# Altium schematic sheet Vref.SchDoc(Vref)
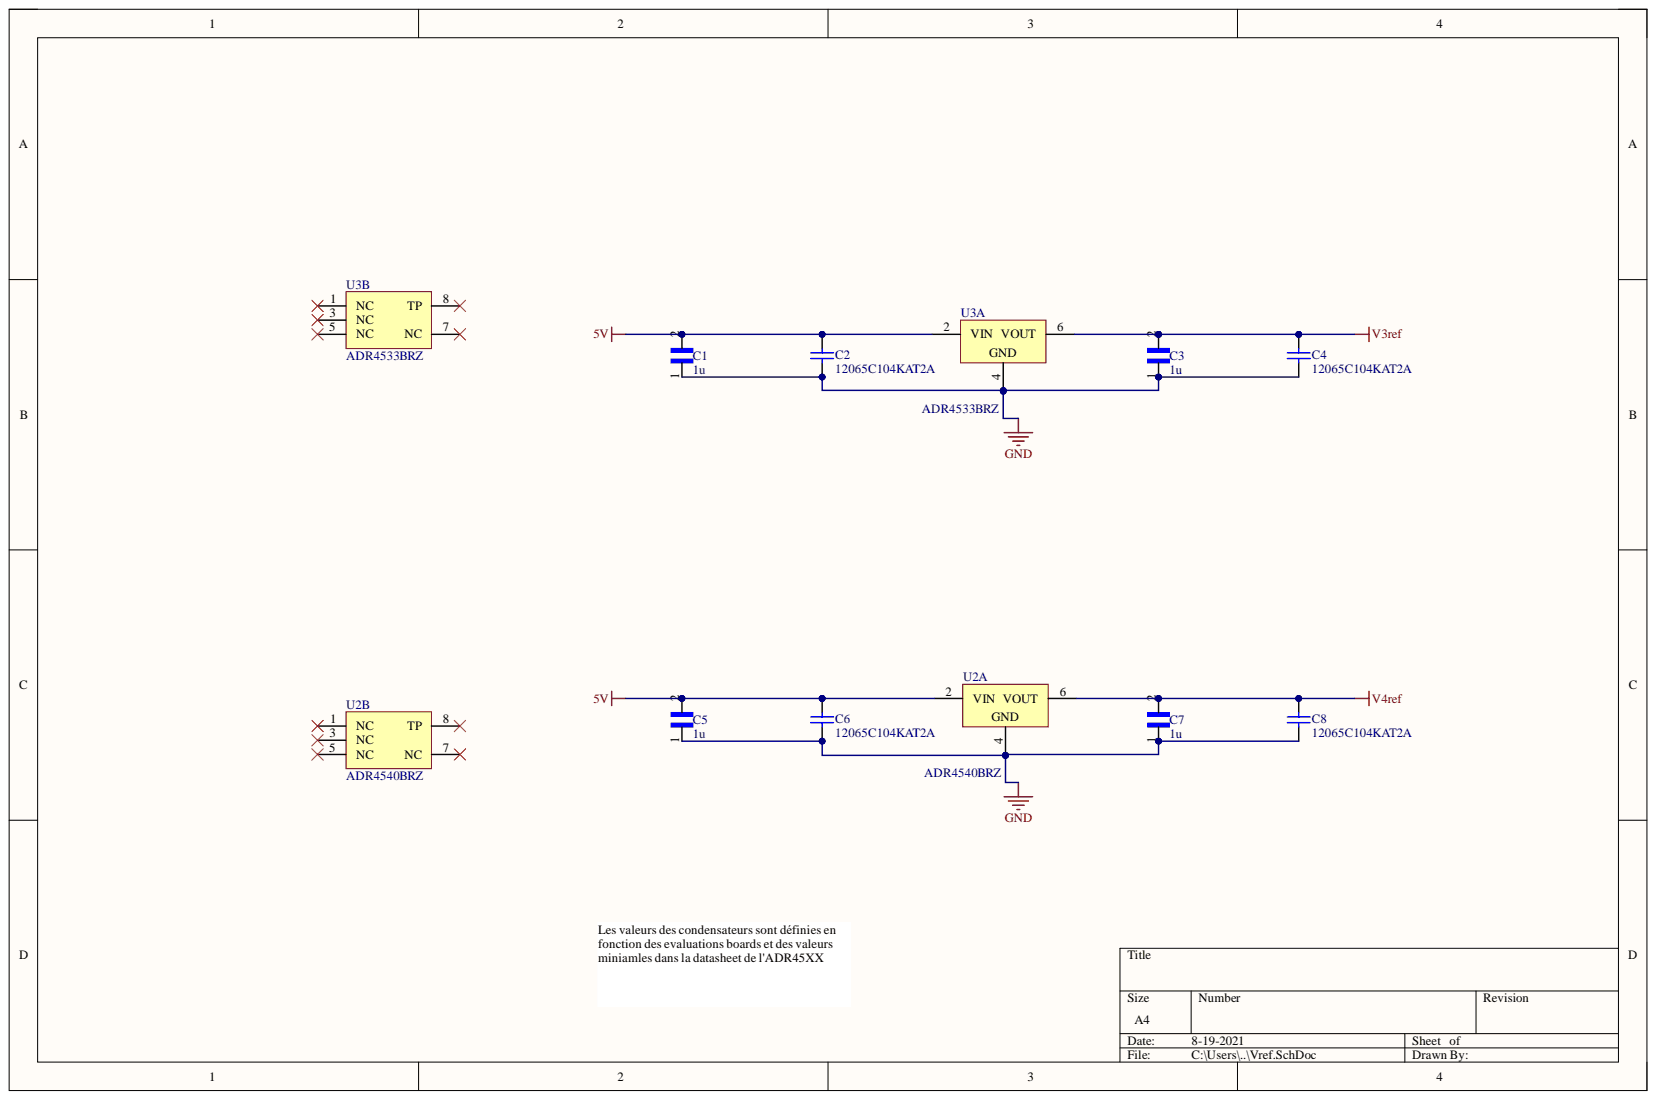
[diagram p0: Vref.SchDoc]

## Parts
C1  1u  [CAPC3216X127N]
C2  12065C104KAT2A  [CAPC3216X94X50ML20T25]
C3  1u  [CAPC3216X127N]
C4  12065C104KAT2A  [CAPC3216X94X50ML20T25]
C5  1u  [CAPC3216X127N]
C6  12065C104KAT2A  [CAPC3216X94X50ML20T25]
C7  1u  [CAPC3216X127N]
C8  12065C104KAT2A  [CAPC3216X94X50ML20T25]
U2  ADR4540BRZ  [ADI-R-8_M]  (parts A,B)
U3  ADR4533BRZ  [ADI-R-8_L]  (parts A,B)

## Nets
5V: C1.2 C2.1 C5.2 C6.1 U2.2(VIN) U3.2(VIN)
GND: C1.1 C2.2 C3.1 C4.2 C5.1 C6.2 C7.1 C8.2 U2.4 U3.4
V3ref: C3.2 C4.1 U3.6(VOUT)
V4ref: C7.2 C8.1 U2.6(VOUT)

Not connected: U2 1(NC) 3(NC) 5(NC) 7(NC) 8(TP); U3 1(NC) 3(NC) 5(NC) 7(NC) 8(TP)

## Library data of the parts
C1 C3 C5 C7 = 1206YC105KAT2A: Cap Ceramic 1uF 16V X7R 10% SMD 1206 125ÂÂŽ T/R; MF: {'manufacturer': u'AVX'}; Availability: Unavailable; Component Kind: Standard; Library Name: 1206YC105KAT2A.SchLib; MP: 1206YC105KAT2A; Package: None; Price: None
C2 C4 C6 C8 = CMP-2000-05018-1: Chip Capacitor, Ceramic, 100 nF, 10%, 50 V, 1206, RoHS, Tape and Reel; Manufacturer: AVX; Capacitance: 100nF; Dielectric: X7R; Rated Voltage: 50V; Case Size/Dimension: 1206; Component Kind: Standard; Datasheet URL: http://www.mouser.com/ds/2/40/cauto-360.pdf; Datasheet Version: Sep-14; Library Name: Altium Content Vault; Manufacturer URL: http://www.avx.com/; Tolerance (%): ±10%
U2 = CMP-0784-00192-1: Ultralow Noise, High Accuracy Voltage Reference, 4.096 V Output, 3 to 15 V Supply, Industrial, 8-pin SOIC (R-8), Tube; Manufacturer: Analog Devices; Max V Supply (V): 15; Min V Supply (V): 3; Ref Out TC (ppm/C): 2; Vout: 4.096V; Code_JEDEC: MS-012-AA; Component Kind: Standard; ComponentLink1Description: Manufacturer URL; ComponentLink1URL: http://www.analog.com/en/index.html; ComponentLink2Description: Datasheet; ComponentLink2URL: http://www.analog.com/static/imported-files/data_sheets/ADR4520_4525_4530_4533_4540_4550.pdf; ComponentLink3Description: Package Specification; ComponentLink3URL: http://www.analog.com/static/imported-files/packages/PKG_PDF/SOIC_Narrow%20(R)/R_8.pdf; ComponentLink4Description: URL Link1; ComponentLink4URL: Product URL:http://www.analog.com/en/special-linear-functions/voltage-references/adr4540/products/product.html; DatasheetVersion: Rev. 0; Library Name: Altium Content Vault; Mounting Technology: Surface Mount; Operating Temp Range: -40 to 125 degC; PackageDescription: 8-Pin Standard Small Outline Package, Body 4.9 x 3.9 mm, Pitch 1.27 mm; PackageReference: R-8; PackageVersion: 060506-A, 06/2006; Packing: Tube; PartNumber: ADR4540BRZ
U3 = CMP-0784-00188-1: Ultralow Noise, High Accuracy Voltage Reference, 3.3 V Output, 3 to 15 V Supply, Industrial, 8-pin SOIC (R-8), Tube; Manufacturer: Analog Devices; Max V Supply (V): 15; Min V Supply (V): 3; Ref Out TC (ppm/C): 2; Vout: 3.3V; Code_JEDEC: MS-012-AA; Component Kind: Standard; ComponentLink1Description: Manufacturer URL; ComponentLink1URL: http://www.analog.com/en/index.html; ComponentLink2Description: Datasheet; ComponentLink2URL: http://www.analog.com/static/imported-files/data_sheets/ADR4520_4525_4530_4533_4540_4550.pdf; ComponentLink3Description: Package Specification; ComponentLink3URL: http://www.analog.com/static/imported-files/packages/PKG_PDF/SOIC_Narrow%20(R)/R_8.pdf; ComponentLink4Description: URL Link1; ComponentLink4URL: Product URL:http://www.analog.com/en/special-linear-functions/voltage-references/adr4533/products/product.html; DatasheetVersion: Rev. 0; Library Name: Altium Content Vault; Mounting Technology: Surface Mount; Operating Temp Range: -40 to 125 degC; PackageDescription: 8-Pin Standard Small Outline Package, Body 4.9 x 3.9 mm, Pitch 1.27 mm; PackageReference: R-8; PackageVersion: 060506-A, 06/2006; Packing: Tube; PartNumber: ADR4533BRZ
